# Altium schematic sheet 02_connector.SchDoc
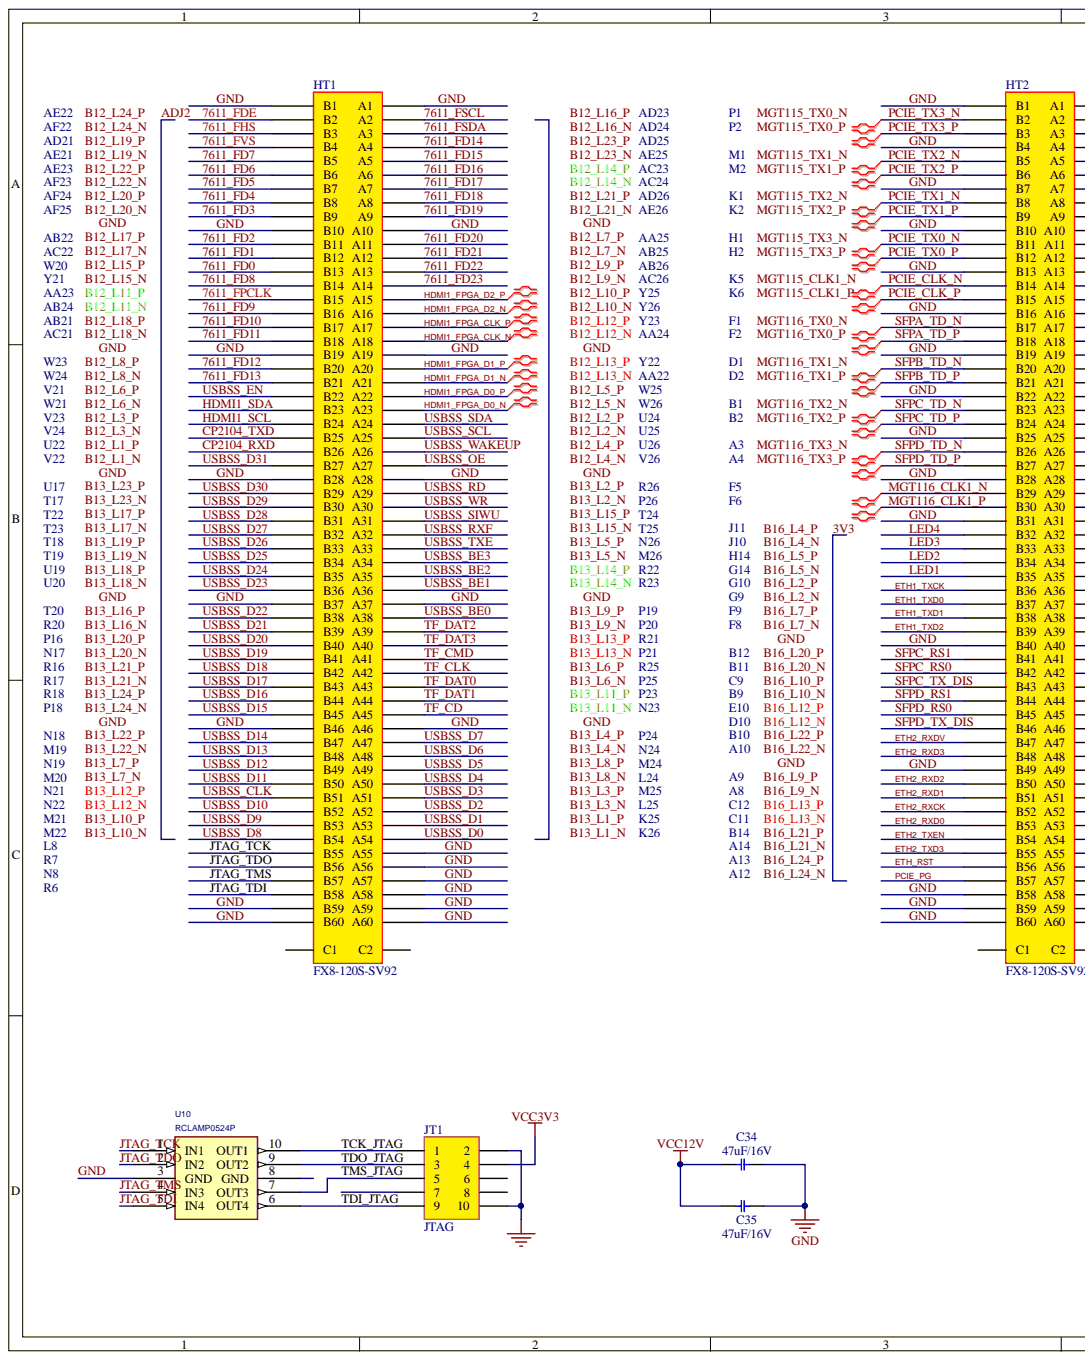
[diagram p0: 02_connector.SchDoc, left]
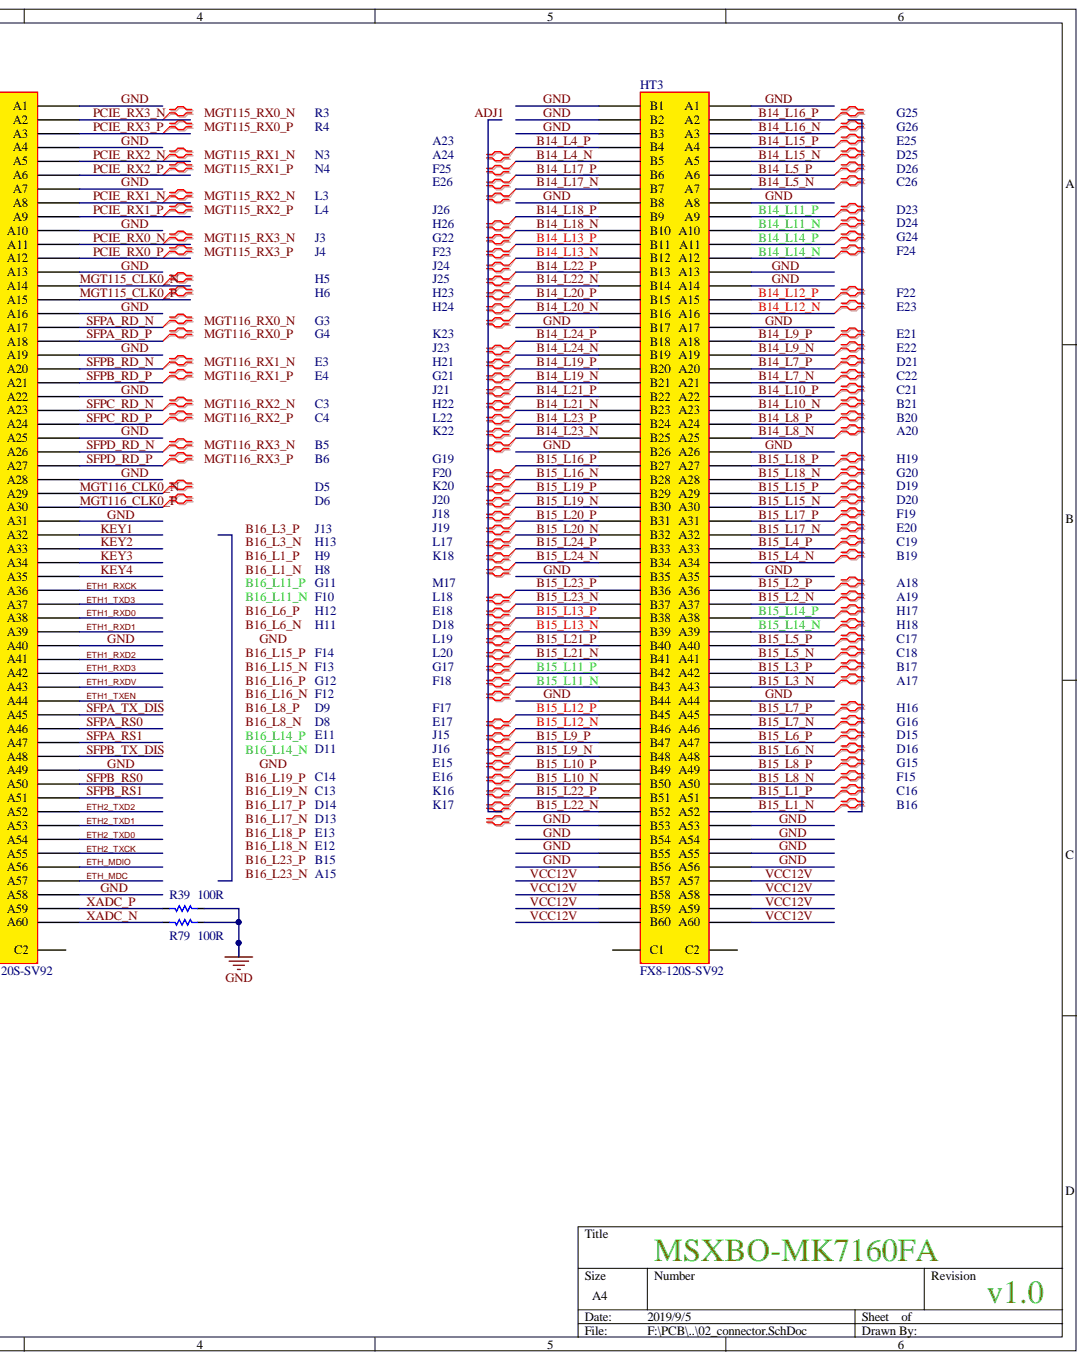
[diagram p1: 02_connector.SchDoc, right]

## Parts
C34  47uF/16V  [c1206]
C35  47uF/16V  [C1206]
HT1  FX8-120S-SV92  [FX8-120S-SV92]
HT2  FX8-120S-SV92  [FX8-120S-SV92]
HT3  FX8-120S-SV92  [FX8-120S-SV92]
JT1  JTAG  [HEADER5X2X2.0T]
R39  100R  [res0402]
R79  100R  [res0402]
U10  RCLAMP0524P  [SLP2510P8_10]

## Nets
VCC3V3: JT1.4
7611_FD0: HT1.B13
7611_FD1: HT1.B12
7611_FD2: HT1.B11
7611_FD3: HT1.B9
7611_FD4: HT1.B8
7611_FD5: HT1.B7
7611_FD6: HT1.B6
7611_FD7: HT1.B5
7611_FD8: HT1.B14
7611_FD9: HT1.B16
7611_FD10: HT1.B17
7611_FD11: HT1.B18
7611_FD12: HT1.B20
7611_FD13: HT1.B21
7611_FD14: HT1.A4
7611_FD15: HT1.A5
7611_FD16: HT1.A6
7611_FD17: HT1.A7
7611_FD18: HT1.A8
7611_FD19: HT1.A9
7611_FD20: HT1.A11
7611_FD21: HT1.A12
7611_FD22: HT1.A13
7611_FD23: HT1.A14
7611_FDE: HT1.B2
7611_FHS: HT1.B3
7611_FPCLK: HT1.B15
7611_FSCL: HT1.A2
7611_FSDA: HT1.A3
7611_FVS: HT1.B4
B14_L4_N: HT3.B5
B14_L4_P: HT3.B4
B14_L5_N: HT3.A7
B14_L5_P: HT3.A6
B14_L7_N: HT3.A21
B14_L7_P: HT3.A20
B14_L8_N: HT3.A25
B14_L8_P: HT3.A24
B14_L9_N: HT3.A19
B14_L9_P: HT3.A18
B14_L10_N: HT3.A23
B14_L10_P: HT3.A22
B14_L11_N: HT3.A10
B14_L11_P: HT3.A9
B14_L12_N: HT3.A16
B14_L12_P: HT3.A15
B14_L13_N: HT3.B12
B14_L13_P: HT3.B11
B14_L14_N: HT3.A12
B14_L14_P: HT3.A11
B14_L15_N: HT3.A5
B14_L15_P: HT3.A4
B14_L16_N: HT3.A3
B14_L16_P: HT3.A2
B14_L17_N: HT3.B7
B14_L17_P: HT3.B6
B14_L18_N: HT3.B10
B14_L18_P: HT3.B9
B14_L19_N: HT3.B21
B14_L19_P: HT3.B20
B14_L20_N: HT3.B16
B14_L20_P: HT3.B15
B14_L21_N: HT3.B23
B14_L21_P: HT3.B22
B14_L22_N: HT3.B14
B14_L22_P: HT3.B13
B14_L23_N: HT3.B25
B14_L23_P: HT3.B24
B14_L24_N: HT3.B19
B14_L24_P: HT3.B18
B15_L1_N: HT3.A52
B15_L1_P: HT3.A51
B15_L2_N: HT3.A37
B15_L2_P: HT3.A36
B15_L3_N: HT3.A43
B15_L3_P: HT3.A42
B15_L4_N: HT3.A34
B15_L4_P: HT3.A33
B15_L5_N: HT3.A41
B15_L5_P: HT3.A40
B15_L6_N: HT3.A48
B15_L6_P: HT3.A47
B15_L7_N: HT3.A46
B15_L7_P: HT3.A45
B15_L8_N: HT3.A50
B15_L8_P: HT3.A49
B15_L9_N: HT3.B48
B15_L9_P: HT3.B47
B15_L10_N: HT3.B50
B15_L10_P: HT3.B49
B15_L11_N: HT3.B43
B15_L11_P: HT3.B42
B15_L12_N: HT3.B46
B15_L12_P: HT3.B45
B15_L13_N: HT3.B39
B15_L13_P: HT3.B38
B15_L14_N: HT3.A39
B15_L14_P: HT3.A38
B15_L15_N: HT3.A30
B15_L15_P: HT3.A29
B15_L16_N: HT3.B28
B15_L16_P: HT3.B27
B15_L17_N: HT3.A32
B15_L17_P: HT3.A31
B15_L18_N: HT3.A28
B15_L18_P: HT3.A27
B15_L19_N: HT3.B30
B15_L19_P: HT3.B29
B15_L20_N: HT3.B32
B15_L20_P: HT3.B31
B15_L21_N: HT3.B41
B15_L21_P: HT3.B40
B15_L22_N: HT3.B52
B15_L22_P: HT3.B51
B15_L23_N: HT3.B37
B15_L23_P: HT3.B36
B15_L24_N: HT3.B34
B15_L24_P: HT3.B33
CP2104_RXD: HT1.B26
CP2104_TXD: HT1.B25
ETH1_RXCK: HT2.A36
ETH1_RXD0: HT2.A38
ETH1_RXD1: HT2.A39
ETH1_RXD2: HT2.A41
ETH1_RXD3: HT2.A42
ETH1_RXDV: HT2.A43
ETH1_TXCK: HT2.B36
ETH1_TXD0: HT2.B37
ETH1_TXD1: HT2.B38
ETH1_TXD2: HT2.B39
ETH1_TXD3: HT2.A37
ETH1_TXEN: HT2.A44
ETH2_RXCK: HT2.B52
ETH2_RXD0: HT2.B53
ETH2_RXD1: HT2.B51
ETH2_RXD2: HT2.B50
ETH2_RXD3: HT2.B48
ETH2_RXDV: HT2.B47
ETH2_TXCK: HT2.A55
ETH2_TXD0: HT2.A54
ETH2_TXD1: HT2.A53
ETH2_TXD2: HT2.A52
ETH2_TXD3: HT2.B55
ETH2_TXEN: HT2.B54
ETH_MDC: HT2.A57
ETH_MDIO: HT2.A56
ETH_RST: HT2.B56
GND: C34.1 C35.1 HT1.A1 HT1.A10 HT1.A19 HT1.A28 HT1.A37 HT1.A46 HT1.A55 HT1.A56 HT1.A57 HT1.A58 HT1.A59 HT1.A60 HT1.B1 HT1.B10 HT1.B19 HT1.B28 HT1.B37 HT1.B46 HT1.B59 HT1.B60 HT2.A1 HT2.A4 HT2.A7 HT2.A10 HT2.A13 HT2.A16 HT2.A19 HT2.A22 HT2.A25 HT2.A28 HT2.A31 HT2.A40 HT2.A49 HT2.A58 HT2.B1 HT2.B4 HT2.B7 HT2.B10 HT2.B13 HT2.B16 HT2.B19 HT2.B22 HT2.B25 HT2.B28 HT2.B31 HT2.B40 HT2.B49 HT2.B58 HT2.B59 HT2.B60 HT3.A1 HT3.A8 HT3.A13 HT3.A14 HT3.A17 HT3.A26 HT3.A35 HT3.A44 HT3.A53 HT3.A54 HT3.A55 HT3.A56 HT3.B1 HT3.B2 HT3.B3 HT3.B8 HT3.B17 HT3.B26 HT3.B35 HT3.B44 HT3.B53 HT3.B54 HT3.B55 HT3.B56 JT1.2 JT1.10 R39.1 R79.1 U10.3
HDMI1_FPGA_CLK_N: HT1.A18
HDMI1_FPGA_CLK_P: HT1.A17
HDMI1_FPGA_D0_N: HT1.A23
HDMI1_FPGA_D0_P: HT1.A22
HDMI1_FPGA_D1_N: HT1.A21
HDMI1_FPGA_D1_P: HT1.A20
HDMI1_FPGA_D2_N: HT1.A16
HDMI1_FPGA_D2_P: HT1.A15
HDMI1_SCL: HT1.B24
HDMI1_SDA: HT1.B23
JTAG_TCK: HT1.B55 U10.1
JTAG_TDI: HT1.B58 U10.5
JTAG_TDO: HT1.B56 U10.2
JTAG_TMS: HT1.B57 U10.4
KEY1: HT2.A32
KEY2: HT2.A33
KEY3: HT2.A34
KEY4: HT2.A35
LED1: HT2.B35
LED2: HT2.B34
LED3: HT2.B33
LED4: HT2.B32
MGT115_CLK0_N: HT2.A14
MGT115_CLK0_P: HT2.A15
MGT116_CLK0_N: HT2.A29
MGT116_CLK0_P: HT2.A30
MGT116_CLK1_N: HT2.B29
MGT116_CLK1_P: HT2.B30
PCIE_CLK_N: HT2.B14
PCIE_CLK_P: HT2.B15
PCIE_PG: HT2.B57
PCIE_RX0_N: HT2.A11
PCIE_RX0_P: HT2.A12
PCIE_RX1_N: HT2.A8
PCIE_RX1_P: HT2.A9
PCIE_RX2_N: HT2.A5
PCIE_RX2_P: HT2.A6
PCIE_RX3_N: HT2.A2
PCIE_RX3_P: HT2.A3
PCIE_TX0_N: HT2.B11
PCIE_TX0_P: HT2.B12
PCIE_TX1_N: HT2.B8
PCIE_TX1_P: HT2.B9
PCIE_TX2_N: HT2.B5
PCIE_TX2_P: HT2.B6
PCIE_TX3_N: HT2.B2
PCIE_TX3_P: HT2.B3
SFPA_RD_N: HT2.A17
SFPA_RD_P: HT2.A18
SFPA_RS0: HT2.A46
SFPA_RS1: HT2.A47
SFPA_TD_N: HT2.B17
SFPA_TD_P: HT2.B18
SFPA_TX_DIS: HT2.A45
SFPB_RD_N: HT2.A20
SFPB_RD_P: HT2.A21
SFPB_RS0: HT2.A50
SFPB_RS1: HT2.A51
SFPB_TD_N: HT2.B20
SFPB_TD_P: HT2.B21
SFPB_TX_DIS: HT2.A48
SFPC_RD_N: HT2.A23
SFPC_RD_P: HT2.A24
SFPC_RS0: HT2.B42
SFPC_RS1: HT2.B41
SFPC_TD_N: HT2.B23
SFPC_TD_P: HT2.B24
SFPC_TX_DIS: HT2.B43
SFPD_RD_N: HT2.A26
SFPD_RD_P: HT2.A27
SFPD_RS0: HT2.B45
SFPD_RS1: HT2.B44
SFPD_TD_N: HT2.B26
SFPD_TD_P: HT2.B27
SFPD_TX_DIS: HT2.B46
TCK_JTAG: JT1.1 U10.10
TDI_JTAG: JT1.9 U10.6
TDO_JTAG: JT1.3 U10.9
TF_CD: HT1.A45
TF_CLK: HT1.A42
TF_CMD: HT1.A41
TF_DAT0: HT1.A43
TF_DAT1: HT1.A44
TF_DAT2: HT1.A39
TF_DAT3: HT1.A40
TMS_JTAG: JT1.5 U10.7
USBSS_BE0: HT1.A38
USBSS_BE1: HT1.A36
USBSS_BE2: HT1.A35
USBSS_BE3: HT1.A34
USBSS_CLK: HT1.B51
USBSS_D0: HT1.A54
USBSS_D1: HT1.A53
USBSS_D2: HT1.A52
USBSS_D3: HT1.A51
USBSS_D4: HT1.A50
USBSS_D5: HT1.A49
USBSS_D6: HT1.A48
USBSS_D7: HT1.A47
USBSS_D8: HT1.B54
USBSS_D9: HT1.B53
USBSS_D10: HT1.B52
USBSS_D11: HT1.B50
USBSS_D12: HT1.B49
USBSS_D13: HT1.B48
USBSS_D14: HT1.B47
USBSS_D15: HT1.B45
USBSS_D16: HT1.B44
USBSS_D17: HT1.B43
USBSS_D18: HT1.B42
USBSS_D19: HT1.B41
USBSS_D20: HT1.B40
USBSS_D21: HT1.B39
USBSS_D22: HT1.B38
USBSS_D23: HT1.B36
USBSS_D24: HT1.B35
USBSS_D25: HT1.B34
USBSS_D26: HT1.B33
USBSS_D27: HT1.B32
USBSS_D28: HT1.B31
USBSS_D29: HT1.B30
USBSS_D30: HT1.B29
USBSS_D31: HT1.B27
USBSS_EN: HT1.B22
USBSS_OE: HT1.A27
USBSS_RD: HT1.A29
USBSS_RXF: HT1.A32
USBSS_SCL: HT1.A25
USBSS_SDA: HT1.A24
USBSS_SIWU: HT1.A31
USBSS_TXE: HT1.A33
USBSS_WAKEUP: HT1.A26
USBSS_WR: HT1.A30
VCC12V: C34.2 C35.2 HT3.A57 HT3.A58 HT3.A59 HT3.A60 HT3.B57 HT3.B58 HT3.B59 HT3.B60
XADC_N: HT2.A60 R79.2
XADC_P: HT2.A59 R39.2
3V3:
A3:
A4:
A8:
A9:
A10:
A12:
A13:
A14:
A15:
A17:
A18:
A19:
A20:
A23:
A24:
AA22:
AA23:
AA24:
AA25:
AB21:
AB22:
AB24:
AB25:
AB26:
AC21:
AC22:
AC23:
AC24:
AC26:
AD21:
AD23:
AD24:
AD25:
AD26:
ADJ1:
ADJ2:
AE21:
AE22:
AE23:
AE25:
AE26:
AF22:
AF23:
AF24:
AF25:
B1:
B2:
B5:
B6:
B9:
B10:
B11:
B12:
B12_L1_N:
B12_L1_P:
B12_L2_N:
B12_L2_P:
B12_L3_N:
B12_L3_P:
B12_L4_N:
B12_L4_P:
B12_L5_N:
B12_L5_P:
B12_L6_N:
B12_L6_P:
B12_L7_N:
B12_L7_P:
B12_L8_N:
B12_L8_P:
B12_L9_N:
B12_L9_P:
B12_L10_N:
B12_L10_P:
B12_L11_N:
B12_L11_P:
B12_L12_N:
B12_L12_P:
B12_L13_N:
B12_L13_P:
B12_L14_N:
B12_L14_P:
B12_L15_N:
B12_L15_P:
B12_L16_N:
B12_L16_P:
B12_L17_N:
B12_L17_P:
B12_L18_N:
B12_L18_P:
B12_L19_N:
B12_L19_P:
B12_L20_N:
B12_L20_P:
B12_L21_N:
B12_L21_P:
B12_L22_N:
B12_L22_P:
B12_L23_N:
B12_L23_P:
B12_L24_N:
B12_L24_P:
B13_L1_N:
B13_L1_P:
B13_L2_N:
B13_L2_P:
B13_L3_N:
B13_L3_P:
B13_L4_N:
B13_L4_P:
B13_L5_N:
B13_L5_P:
B13_L6_N:
B13_L6_P:
B13_L7_N:
B13_L7_P:
B13_L8_N:
B13_L8_P:
B13_L9_N:
B13_L9_P:
B13_L10_N:
B13_L10_P:
B13_L11_N:
B13_L11_P:
B13_L12_N:
B13_L12_P:
B13_L13_N:
B13_L13_P:
B13_L14_N:
B13_L14_P:
B13_L15_N:
B13_L15_P:
B13_L16_N:
B13_L16_P:
B13_L17_N:
B13_L17_P:
B13_L18_N:
B13_L18_P:
B13_L19_N:
B13_L19_P:
B13_L20_N:
B13_L20_P:
B13_L21_N:
B13_L21_P:
B13_L22_N:
B13_L22_P:
B13_L23_N:
B13_L23_P:
B13_L24_N:
B13_L24_P:
B14:
B15:
B16:
B16_L1_N:
B16_L1_P:
B16_L2_N:
B16_L2_P:
B16_L3_N:
B16_L3_P:
B16_L4_N:
B16_L4_P:
B16_L5_N:
B16_L5_P:
B16_L6_N:
B16_L6_P:
B16_L7_N:
B16_L7_P:
B16_L8_N:
B16_L8_P:
B16_L9_N:
B16_L9_P:
B16_L10_N:
B16_L10_P:
B16_L11_N:
B16_L11_P:
B16_L12_N:
B16_L12_P:
B16_L13_N:
B16_L13_P:
B16_L14_N:
B16_L14_P:
B16_L15_N:
B16_L15_P:
B16_L16_N:
B16_L16_P:
B16_L17_N:
B16_L17_P:
B16_L18_N:
B16_L18_P:
B16_L19_N:
B16_L19_P:
B16_L20_N:
B16_L20_P:
B16_L21_N:
B16_L21_P:
B16_L22_N:
B16_L22_P:
B16_L23_N:
B16_L23_P:
B16_L24_N:
B16_L24_P:
B17:
B19:
B20:
B21:
C3:
C4:
C9:
C11:
C12:
C13:
C14:
C16:
C17:
C18:
C19:
C21:
C22:
C26:
D1:
D2:
D5:
D6:
D8:
D9:
D10:
D11:
D13:
D14:
D15:
D16:
D18:
D19:
D20:
D21:
D23:
D24:
D25:
D26:
E3:
E4:
E10:
E11:
E12:
E13:
E15:
E16:
E17:
E18:
E20:
E21:
E22:
E23:
E25:
E26:
F1:
F2:
F5:
F6:
F8:
F9:
F10:
F12:
F13:
F14:
F15:
F17:
F18:
F19:
F20:
F22:
F23:
F24:
F25:
G3:
G4:
G9:
G10:
G11:
G12:
G14:
G15:
G16:
G17:
G19:
G20:
G21:
G22:
G24:
G25:
G26:
H1:
H2:
H5:
H6:
H8:
H9:
H11:
H12:
H13:
H14:
H16:
H17:
H18:
H19:
H21:
H22:
H23:
H24:
H26:
J3:
J4:
J10:
J11:
J13:
J15:
J16:
J18:
J19:
J20:
J21:
J23:
J24:
J25:
J26:
K1:
K2:
K5:
K6:
K16:
K17:
K18:
K20:
K22:
K23:
K25:
K26:
L3:
L4:
L8:
L17:
L18:
L19:
L20:
L22:
L24:
L25:
M1:
M2:
M17:
M19:
M20:
M21:
M22:
M24:
M25:
M26:
MGT115_CLK1_N:
MGT115_CLK1_P:
MGT115_RX0_N:
MGT115_RX0_P:
MGT115_RX1_N:
MGT115_RX1_P:
MGT115_RX2_N:
MGT115_RX2_P:
MGT115_RX3_N:
MGT115_RX3_P:
MGT115_TX0_N:
MGT115_TX0_P:
MGT115_TX1_N:
MGT115_TX1_P:
MGT115_TX2_N:
MGT115_TX2_P:
MGT115_TX3_N:
MGT115_TX3_P:
MGT116_RX0_N:
MGT116_RX0_P:
MGT116_RX1_N:
MGT116_RX1_P:
MGT116_RX2_N:
MGT116_RX2_P:
MGT116_RX3_N:
MGT116_RX3_P:
MGT116_TX0_N:
MGT116_TX0_P:
MGT116_TX1_N:
MGT116_TX1_P:
MGT116_TX2_N:
MGT116_TX2_P:
MGT116_TX3_N:
MGT116_TX3_P:
N3:
N4:
N8:
N17:
N18:
N19:
N21:
N22:
N23:
N24:
N26:
N00568:
N00569:
N00570:
N00571:
P1:
P2:
P16:
P18:
P19:
P20:
P21:
P23:
P24:
P25:
P26:
R3:
R4:
R6:
R7:
R16:
R17:
R18:
R20:
R21:
R22:
R23:
R25:
R26:
T17:
T18:
T19:
T20:
T22:
T23:
T24:
T25:
U17:
U19:
U20:
U22:
U24:
U25:
U26:
V21:
V22:
V23:
V24:
V26:
W20:
W21:
W23:
W24:
W25:
W26:
Y21:
Y22:
Y23:
Y25:
Y26:

Not connected: HT1 C1 C2; HT2 C1 C2; HT3 C1 C2; JT1 6 7 8; U10 8

## Library data of the parts
C34 C35 = CAP_47uF/16V: Capacitor; Component Kind: Standard; Library Name: MK7X(FUN)20190418.IntLib
HT1-HT3 = FX8-120P-SV92: Component Kind: Standard; Library Name: A7_Fun_Library.SchLib
JT1 = HEADER2X5: Component Kind: Standard; Library Name: mis607.IntLib
R39 R79 = RES_0/1%: Res; Component Kind: Standard; Library Name: MK7X(FUN)20190418.IntLib
U10 = RCLAMP0524P: Component Kind: Standard
